# Altium schematic sheet Top_Level.SchDoc(Top_Level)
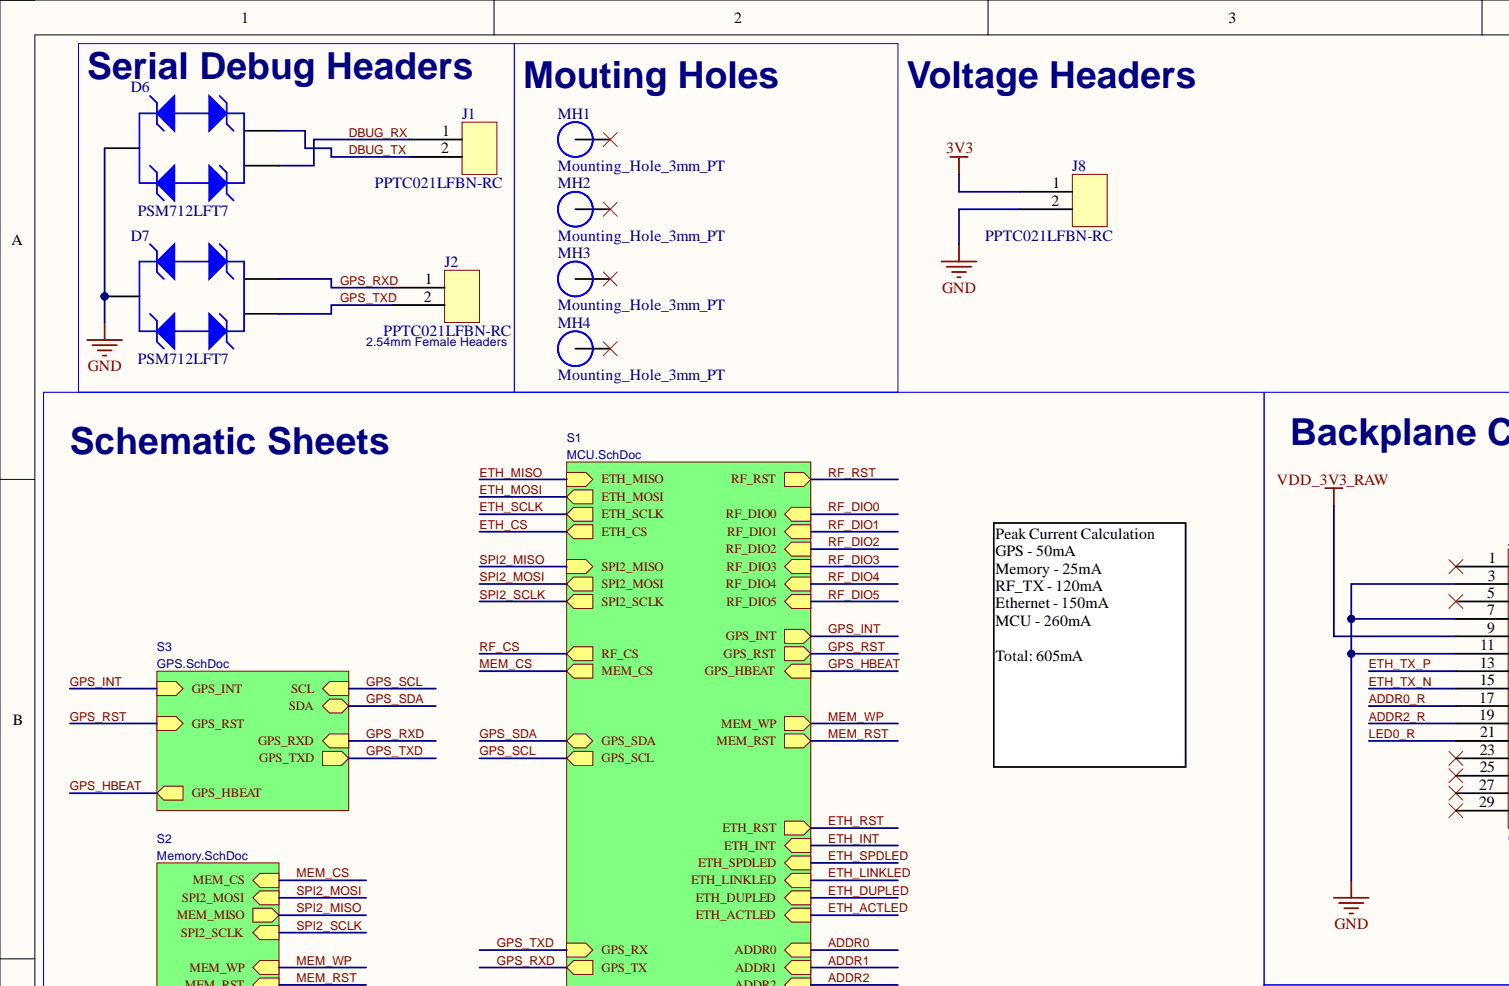
[diagram p0: Top_Level.SchDoc, top left]
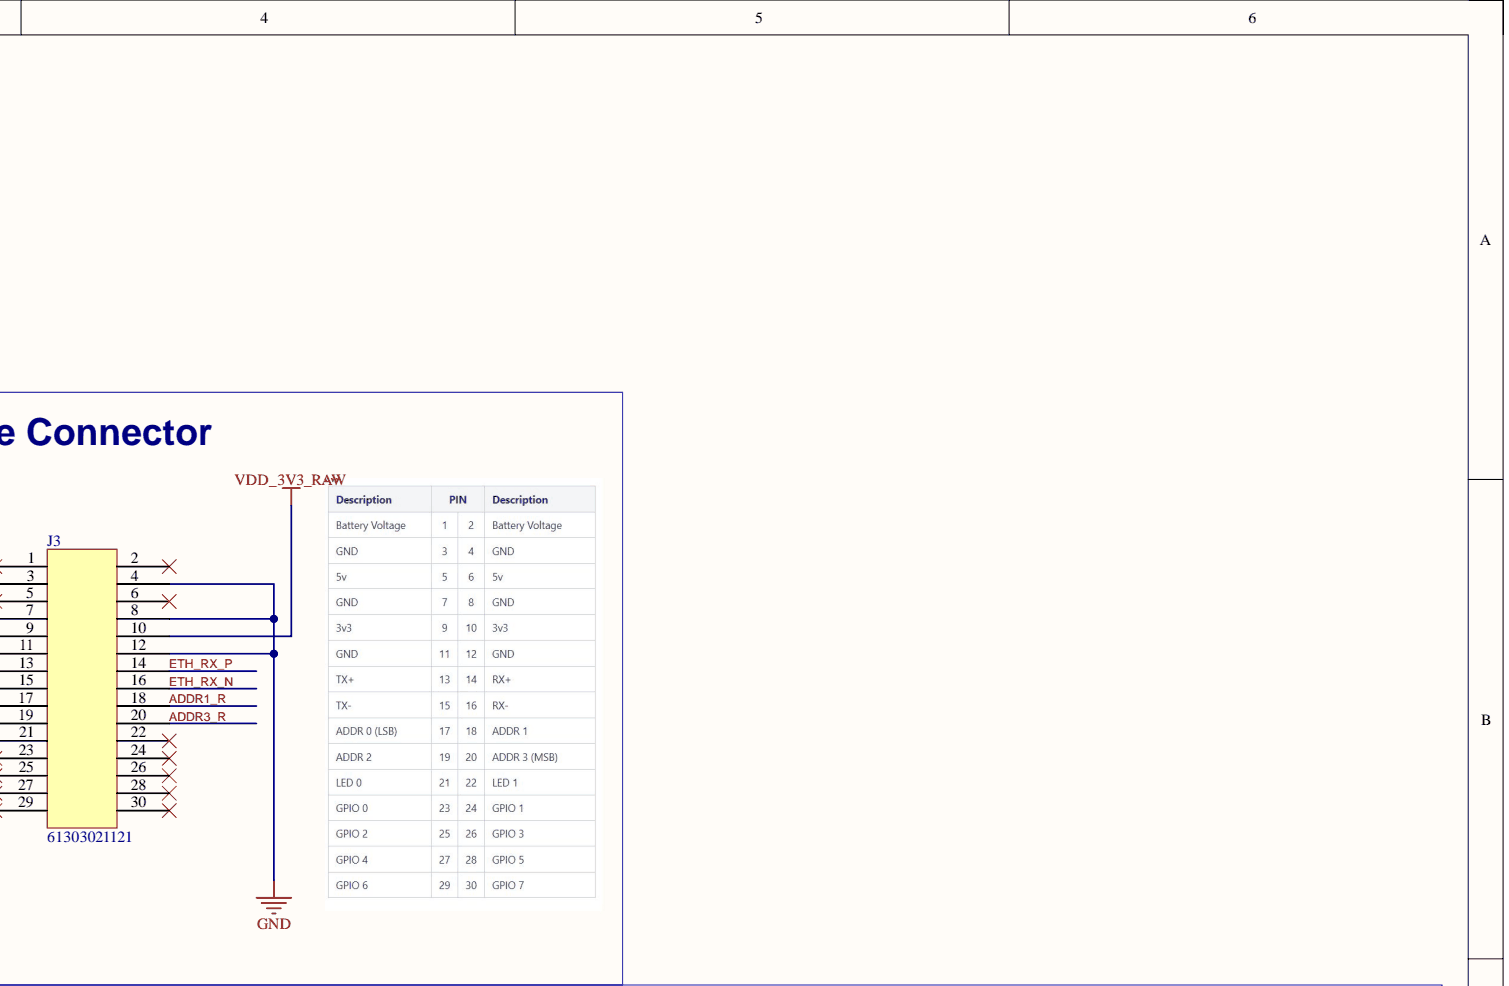
[diagram p1: Top_Level.SchDoc, top right]
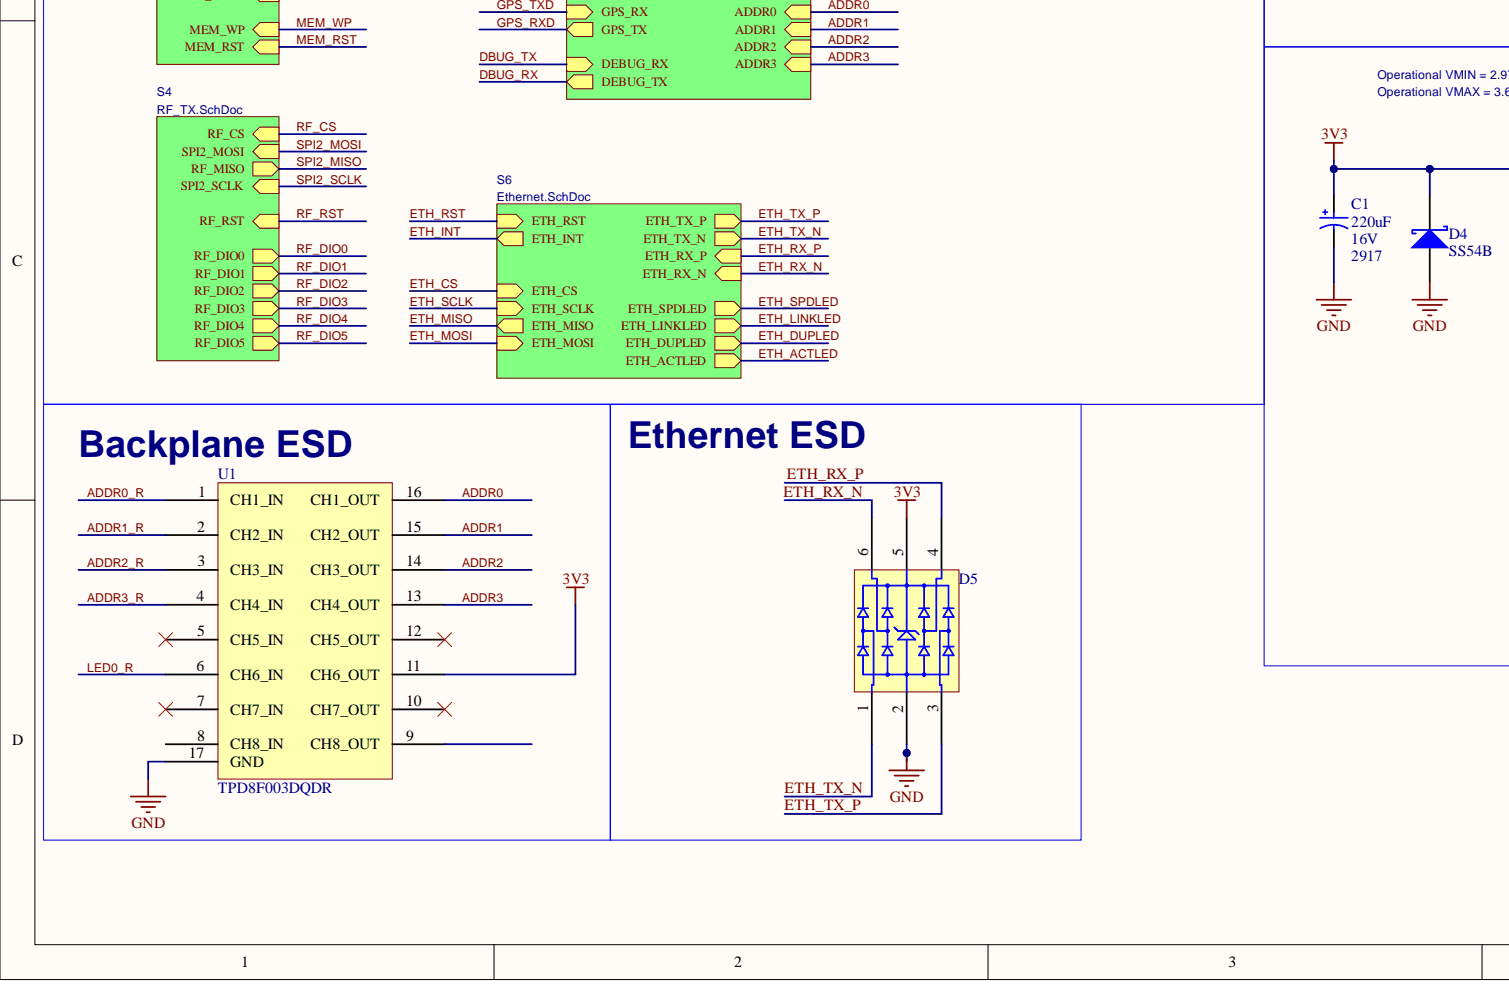
[diagram p2: Top_Level.SchDoc, bottom left]
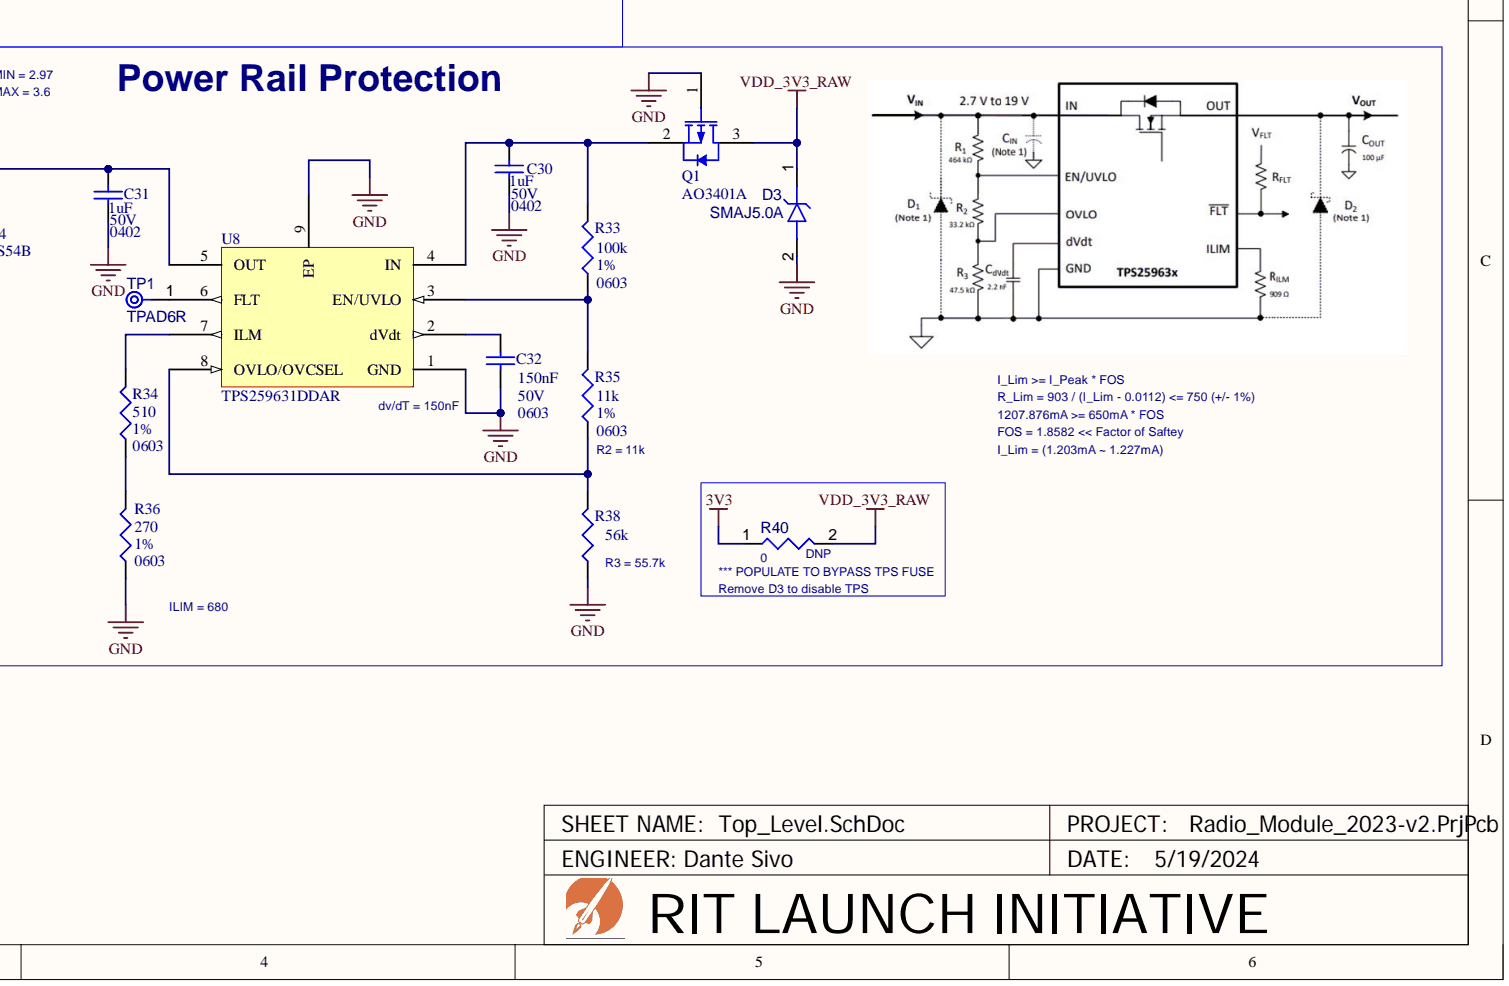
[diagram p3: Top_Level.SchDoc, bottom right]

## Parts
C1  Capacitance: 220uF  Case/Package: 2917  Voltage: 16V  (hidden comment: TAJE227K016RNJ)
C30  Capacitance: 1uF  Case/Package: 0402  Voltage: 50V  (hidden comment: CL05A105KA5NQNC)
C31  Capacitance: 1uF  Case/Package: 0402  Voltage: 50V  (hidden comment: CL05A105KA5NQNC)
C32  Capacitance: 150nF  Case/Package: 0603  Voltage: 50V  (hidden comment: GCM188R71H154KA64D)
D3  SMAJ5.0A
D4  SS54B
D5  SRV05-4-P-T7  (value not drawn)
D6  PSM712LFT7
D7  PSM712LFT7
J1  PPTC021LFBN-RC
J2  PPTC021LFBN-RC  Pitch: 2.54mm
J3  61303021121
J8  PPTC021LFBN-RC
MH1  Mounting_Hole_3mm_PT
MH2  Mounting_Hole_3mm_PT
MH3  Mounting_Hole_3mm_PT
MH4  Mounting_Hole_3mm_PT
Q1  AO3401A
R33  Case/Package: 0603  Resistance: 100k  Tolerance: 1%  (hidden comment: 0603WAF1003T5E)
R34  Case/Package: 0603  Tolerance: 1%  (hidden comment: 0603WAF5100T5E)
R35  Case/Package: 0603  Resistance: 11k  Tolerance: 1%  (hidden comment: ERJ-3EKF1102V)
R36  Case/Package: 0603  Tolerance: 1%  (hidden comment: 0603WAF2700T5E)
R38  Resistance: 56k  (hidden comment: 0805W8F5602T5E)
R40  value 0  (hidden comment: Jumper 0603)
TP1  TPAD6R  (no BOM)
U1  TPD8F003DQDR
U8  TPS259631DDAR

## Nets
3V3: C1.1 C31.2 D4.2 D5.5 J8.1 R40.1 U1.11(CH6_OUT) U8.5(OUT)
GND: C1.2 C30.1 C31.1 C32.1 D3.2 D4.1 D5.2 D6.3 D7.3 J3.3 J3.4 J3.7 J3.8 J3.11 J3.12 J8.2 Q1.1 R36.1 R38.1 U1.17(GND) U8.1(GND) U8.9(EP)
VDD_3V3_RAW: D3.1 J3.9 J3.10 Q1.3 R40.2
ADDR0: U1.16(CH1_OUT)
ADDR0_R: J3.17 U1.1(CH1_IN)
ADDR1: U1.15(CH2_OUT)
ADDR1_R: J3.18 U1.2(CH2_IN)
ADDR2: U1.14(CH3_OUT)
ADDR2_R: J3.19 U1.3(CH3_IN)
ADDR3: U1.13(CH4_OUT)
ADDR3_R: J3.20 U1.4(CH4_IN)
DBUG_RX: D6.2 J1.1
DBUG_TX: D6.1 J1.2
ETH_RX_N: D5.6 J3.16
ETH_RX_P: D5.4 J3.14
ETH_TX_N: D5.1 J3.15
ETH_TX_P: D5.3 J3.13
GPS_RXD: D7.1 J2.1
GPS_TXD: D7.2 J2.2
LED0_R: J3.21 U1.6(CH6_IN)
NetC30_2: C30.2 Q1.2 R33.2 U8.4(IN)
NetC32_2: C32.2 U8.2(dVdt)
NetJ3_1: J3.1
NetJ3_2: J3.2
NetJ3_5: J3.5
NetJ3_6: J3.6
NetJ3_22: J3.22
NetJ3_23: J3.23
NetJ3_24: J3.24
NetJ3_25: J3.25
NetJ3_26: J3.26
NetJ3_27: J3.27
NetJ3_28: J3.28
NetJ3_29: J3.29
NetJ3_30: J3.30
NetMH1_1: MH1.1
NetMH2_1: MH2.1
NetMH3_1: MH3.1
NetMH4_1: MH4.1
NetR33_1: R33.1 R35.2 U8.3(EN/UVLO)
NetR34_1: R34.1 R36.2
NetR34_2: R34.2 U8.7(ILM)
NetR35_1: R35.1 R38.2 U8.8(OVLO/OVCSEL)
NetTP1_1: TP1.1 U8.6(FLT)
NetU1_5: U1.5(CH5_IN)
NetU1_7: U1.7(CH7_IN)
NetU1_8: U1.8(CH8_IN)
NetU1_9: U1.9(CH8_OUT)
NetU1_10: U1.10(CH7_OUT)
NetU1_12: U1.12(CH5_OUT)
ETH_ACTLED:
ETH_CS:
ETH_DUPLED:
ETH_INT:
ETH_LINKLED:
ETH_MISO:
ETH_MOSI:
ETH_RST:
ETH_SCLK:
ETH_SPDLED:
GPS_HBEAT:
GPS_INT:
GPS_RST:
GPS_SCL:
GPS_SDA:
MEM_CS:
MEM_RST:
MEM_WP:
RF_CS:
RF_DIO0:
RF_DIO1:
RF_DIO2:
RF_DIO3:
RF_DIO4:
RF_DIO5:
RF_RST:
SPI2_MISO:
SPI2_MOSI:
SPI2_SCLK:

## Library data of the parts
C1 = CMP-001-0314-1: CAP TANT 220UF 10% 16V 2917; Dielectric Material: Tantalum; ESR (Equivalent Series Resistance): 500mR; Leakage Current: 35.2uA; Polarity: Polar; Voltage Rating (DC): 16.3V; Component Kind: Standard; Construction: Flat; Depth: 4.3mm; Dissipation Factor: 10%; Features: General Purpose; Height: 4.1mm; Height - Seated (Max): 0.169inch; JLCPCB Part #: C7231; Lead Free: Lead Free; Length: 7.3mm; Max Operating Temperature: 125°C; Min Operating Temperature: -55°C; Mounting Technology: Surface Mount; Package Quantity: 400; Packaging: Tape and Reel; Pins: 2; Radiation Hardening: No; Reach SVHC Compliant: Yes; RoHS Compliant: Yes; Series: TAJ; Termination: SMD/SMT; Tolerance: 10%; Voltage Rating: 16V; Width: 4.3mm; Library Name: RIT Launch Initiative
C30 C31 = CMP-001-0296-1: CAP CER 1uF 50V X5R 0402; Material: Ceramic; Tolerance: 10%; Component Kind: Standard; Dielectric: X5R; JLCPCB Part #: C52923; Max Operating Temperature: 85°C; Min Operating Temperature: -55°C; Mounting Technology: Surface Mount; Series: CL; Library Name: RIT Launch Initiative
C32 = CMP-001-0154-1: CAP CER 0.15UF 50V X7R 0603; Component Kind: Standard; JLCPCB Part #: C161224; Library Name: RIT Launch Initiative
D3 = CMP-001-0326-1: SMAJ Series 9.2 V 400 W Surface Mount Uni-Directional TVS Diode - DO-214AC; Breakdown Voltage: 7V; Clamping Voltage: 9.2V; Diode Type: ZENER; Direction: Unidirectional; Element Configuration: Single; Leakage Current: 800uA; Max Power Dissipation: 400W; Max Surge Current: 43.48A; Min Breakdown Voltage: 6.4V; Number of Channels: 1; Operating Supply Voltage: 5V; Power Line Protection: No; Test Current: 10mA; Case/Package: DO-214AC; Component Kind: Standard; JLCPCB Part #: C2925443; Max Breakdown Voltage: 7V; Max Operating Temperature: 150°C; Max Reverse Leakage Current: 800uA; Min Operating Temperature: -55°C; Mounting Technology: Surface Mount; Number of Elements: 1; Number of Unidirectional Channels: 1; Package Quantity: 1; Packaging: Cut Tape; Peak Pulse Current: 43.48A; Peak Pulse Power: 400W; Pins: 2; Polarity: Unidirectional; Radiation Hardening: No; Reach SVHC Compliant: Yes; Resistor Type: Zener; Reverse Breakdown Voltage: 6.4V; Reverse Standoff Voltage: 5V; RoHS Compliant: Yes; Series: -; Termination: SMD/SMT; Library Name: RIT Launch Initiative
D4 = CMP-004-00006-2: Schottky Barrier Rectifier, 40 V, 5 A, -55 to 150 degC, 2-Pin SMD; Manufacturer: JCET; Case/Package: SMB-2; Component Kind: Standard; Datasheet URL: http://wiki.seeedstudio.com/images/3/3f/0310130P1.pdf; Datasheet Version: May-13; JLCPCB part #: C22452; Manufacturer URL: http://www.cj-elec.com/; Mounting Technology: SM; Package Description: 2-Pin Surface Mount Device, Body 4.315 x 3.62 mm; Package Reference: SMB-2; RoHS Compliant: Unknown; Library Name: RIT Launch Initiative
D5 = CMP-001-0239-4: SRV05 Series 4 Ch 3.5 pF 15 Vclamp Steering Diode/TVS Array Combo - SOT-23-6; Capacitance: 3.5pF; Clamping Voltage: 15V; Direction: Unidirectional; Leakage Current: 5uA; Number of Channels: 4; Number of Elements: 1; Peak Pulse Current: 5A; Peak Pulse Power: 500W; Reverse Breakdown Voltage: 6V; Reverse Standoff Voltage: 5V; Test Current: 1mA; Case/Package: SOT-23; Clamping Voltage-Max: 15V; Component Kind: Standard; Depth: 1.75mm; JLCPCB Part #: C85364; Lead Free: Lead Free; Length: 3.05mm; Max Operating Temperature: 150°C; Min Operating Temperature: -55°C; Mount: Surface Mount; Number of Pins: 6; Packaging: Tape and Reel; Polarity: Unidirectional; Radiation Hardening: No; RoHS Compliant: Yes; Working Voltage: 5V; Library Name: RIT Launch Initiative
D6 D7 = CMP-001-0303-2: TVS Diode, 600W, 7V V(RWM), Bidirectional, 2 Element, Silicon; Voltage: 19V; Component Kind: Standard; JLCPCB Part #: C32677; Library Name: RIT Launch Initiative
J1 J8 = CMP-001-0336-1: CONN HDR 2POS 0.1 TIN PCB; Connector Type: Header; Number of Positions: 2; Pitch: 2.54mm; Voltage Rating: 250V; Color: Black; Component Kind: Standard; Contact Finish - Mating: TIN; Contact Material: Bronze; Contact Plating: Tin; Contact Shape: Square; Contact Type: Female Socket; Fastening Type: Pull; Flammability Rating: UL94 V-0; Height: 8.5mm; Housing Material: Plastic; Insulation Color: Black; Insulation Material: Polyester; Lead Free: Lead Free; Length: 5.58mm; Max Operating Temperature: 105°C; Min Operating Temperature: -40°C; Mounting Technology: Through Hole; Number of Rows: 1; Package Quantity: 1000; Packaging: Tray; RoHS Compliant: Yes; Series: -; Termination: Solder; Width: 2.5mm; Library Name: RIT Launch Initiative
J2 = CMP-001-0336-1: CONN HDR 2POS 0.1 TIN PCB; Connector Type: Header; Number of Positions: 2; Voltage Rating: 250V; Color: Black; Component Kind: Standard; Contact Finish - Mating: TIN; Contact Material: Bronze; Contact Plating: Tin; Contact Shape: Square; Contact Type: Female Socket; Fastening Type: Pull; Flammability Rating: UL94 V-0; Height: 8.5mm; Housing Material: Plastic; Insulation Color: Black; Insulation Material: Polyester; Lead Free: Lead Free; Length: 5.58mm; Max Operating Temperature: 105°C; Min Operating Temperature: -40°C; Mounting Technology: Through Hole; Number of Rows: 1; Package Quantity: 1000; Packaging: Tray; RoHS Compliant: Yes; Series: -; Termination: Solder; Width: 2.5mm; Library Name: RIT Launch Initiative
J3 = CMP-001-0328-2: CONN HEADER VERT 30POS 2.54MM; Gender: Male; Number of Contacts: 30; Pitch: 0.1inch; Voltage Rating: 250V; Color: Black; Component Kind: Standard; Connector Type: Header; Contact Finish - Mating: GOLD; Contact Material: Copper Alloy,Copper; Contact Mating Length: 0.236inch; Contact Plating: Gold; Contact Shape: Square; Contact Type: Male Pin; Fastening Type: Pull; Flammability Rating: UL94 V-0; Insulation Color: Black; Insulation Material: Nylon; JLCPCB Part #: C56496; Max Operating Temperature: 105°C; Min Operating Temperature: -40°C; Mounting Technology: Through Hole; Number of Positions: 30; Number of Rows: 2; Packaging: Bulk; RoHS Compliant: Yes; Row Spacing: 0.1inch; Series: WR-PHD; Termination: Solder; Library Name: RIT Launch Initiative
MH1-MH4 = CMP-001-0289-1: 3mm Screw, 6.5mm Head, Plated Mounting Hole; Component Kind: Standard; Library Name: RIT Launch Initiative
Q1 = CMP-001-0310-2: MOSFET P-CH 30V 4A SOT23-3L; Input Capacitance: 1.2nF; Max Power Dissipation: 1.4W; Number of Elements: 1; Rds On Max: 44mR; Case/Package: TO-236-3; Component Kind: Standard; Continuous Drain Current (ID): 4A; Drain to Source Voltage (Vdss): 30V; JLCPCB Part #: C15127; Lead Free: Lead Free; Max Operating Temperature: 150°C; Min Operating Temperature: -55°C; Mounting Technology: Surface Mount; Packaging: Cut Tape; Pins: 3; Power Dissipation: 1.4W; Radiation Hardening: No; RoHS Compliant: Yes; Series: -; Library Name: RIT Launch Initiative
R33 = CMP-001-0325-1: RES 100K OHM 1% 1/10W 0603; Component Kind: Standard; JLCPCB Part #: C25803; RoHS Compliant: Yes; Library Name: RIT Launch Initiative
R34 = CMP-001-0248-2: RES 510 OHM 1% 1/10W 0603; Resistance: 510; Component Kind: Standard; JLCPCB Part #: C23193; RoHS Compliant: Yes; Library Name: RIT Launch Initiative
R35 = CMP-001-0138-1: RES SMD 11K OHM 1% 1/10W 0603; Component Kind: Standard; JLCPCB Part #: C25950; Library Name: RIT Launch Initiative
R36 = CMP-001-0308-1: RES 270 OHM 1% 1/10W 0603; Resistance: 270; Component Kind: Standard; JLCPCB Part #: C22966; Library Name: RIT Launch Initiative
R38 = CMP-001-0333-2: 125mW Thick Film Resistors 150V ±100ppm/℃ ±1% -55℃~+155℃ 56kΩ 0805  Chip Resistor - Surface Mount ROHS; Component Kind: Standard; JLCPCB Part #: C17756; Package: 0805; Voltage: 150V; Library Name: RIT Launch Initiative
R40 = CMP-009-00175-2: Case/Package: 0603; Component Kind: Standard; Description: Jumper 0603; Max Operating Temperature: 155°C; Min Operating Temperature: -55°C; Pins: 2; Library Name: RIT Launch Initiative
TP1 = CMP-00028-00002-1: 0.6mm round; Pins: 1; Library Name: RIT Launch Initiative
U1 = CMP-001-0313-1: FILTER RC(PI) 100 OHM/8.5PF SMD; Attenuation Value: 25dB; ESD Protection: Yes; Frequency: 200MHz; Min Breakdown Voltage: 6V; Number of Channels: 8; Number of Elements: 16; Operating Supply Voltage: 5.5V; Value: 100R; Case/Package: SMD/SMT; Circuit Type: Filter; Component Kind: Standard; Contact Plating: Gold; Depth: 1.45mm; Height: 0.8mm; JLCPCB Part #: C473368; Lead Free: Lead Free; Length: 3.4mm; Max Operating Temperature: 85°C; Min Operating Temperature: -40°C; Operating Frequency: 200MHz; Package Quantity: 3000; Packaging: Tape and Reel; Pins: 16; Radiation Hardening: No; Reach SVHC Compliant: Yes; Series: TPD; Termination: Flat; Width: 1.35mm; Library Name: RIT Launch Initiative
U8 = CMP-001-0330-4: 2.7V to 19 V 0.125A to 2A 89mOhm eFuse with Accurate Current Monitor and Fast Overvoltage Protection 8-Pin SOIC...; Accuracy: 10.4%; Component Kind: Standard; JLCPCB Part #: C2155778; Max Operating Temperature: 125°C; Min Operating Temperature: -40°C; Mounting Technology: Surface Mount; Output Current: 2A; Pins: 8; Series: -; Library Name: RIT Launch Initiative
